# Altium schematic sheet Schematic.SchDoc
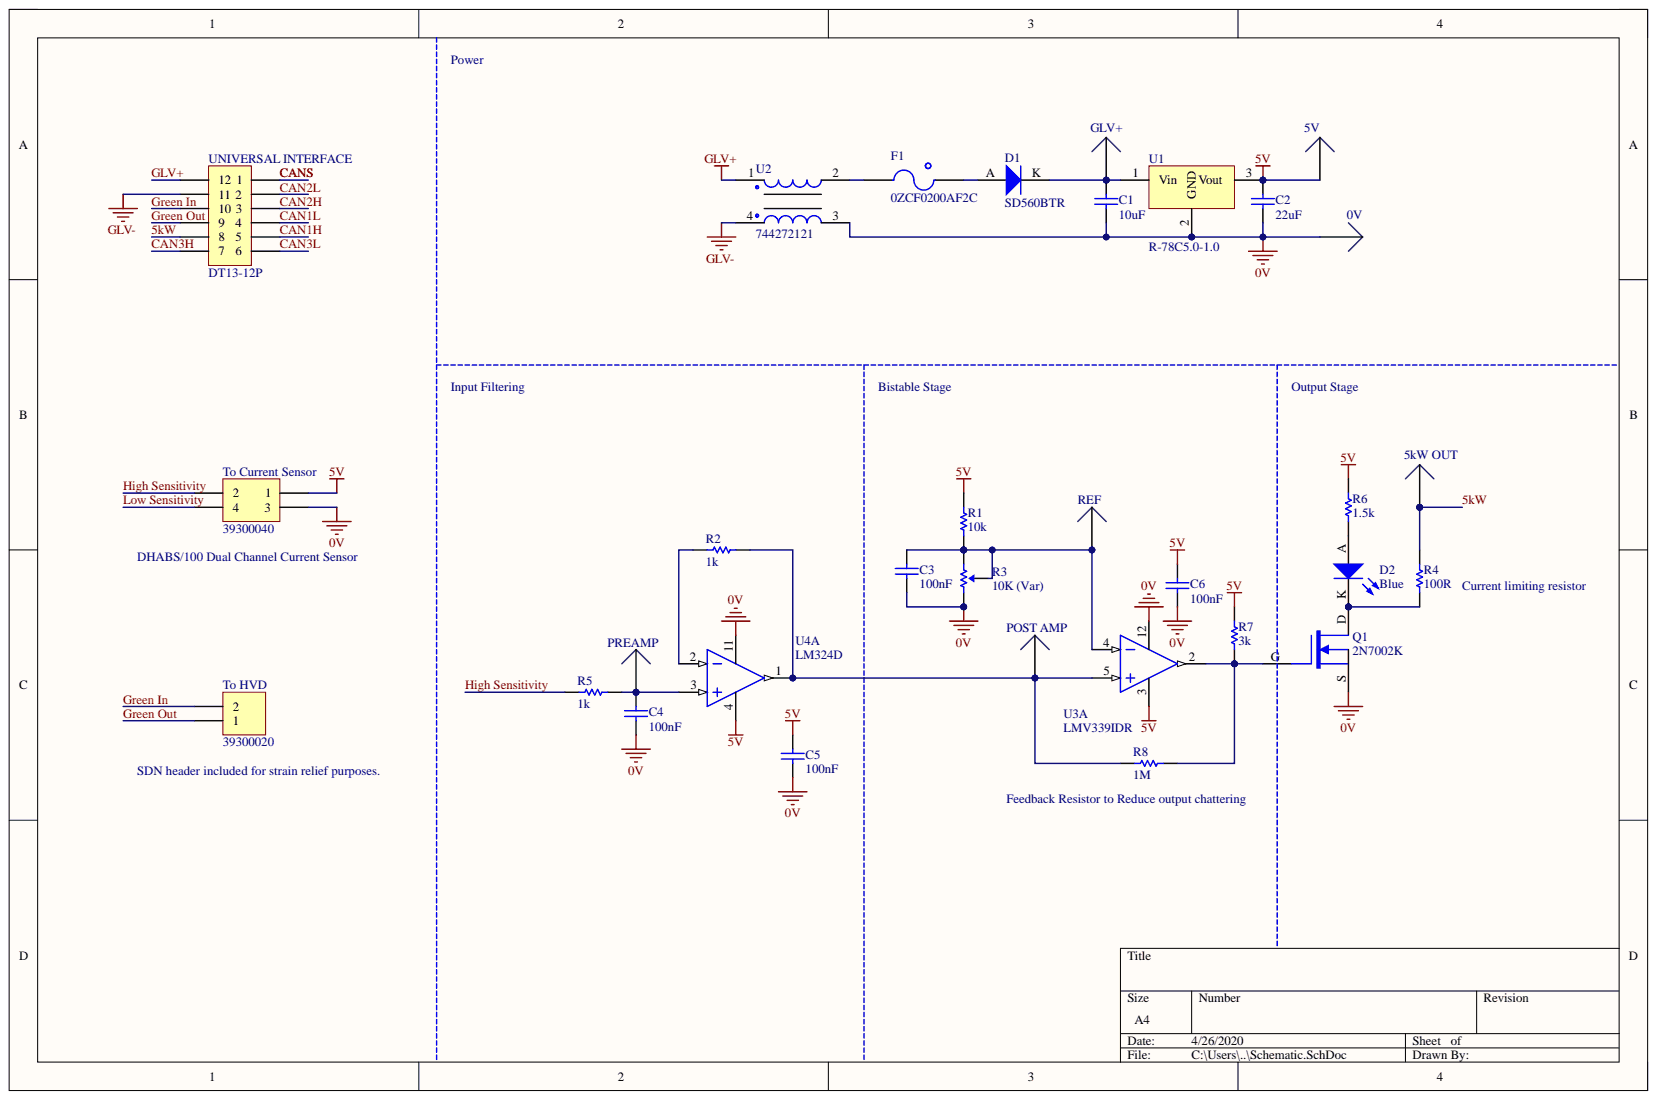
[diagram p0: Schematic.SchDoc]

## Parts
0V  0mm  [TH Test Point]  (value not drawn)
5kW_OUT  0mm  [TH Test Point]  (value not drawn)
5V  0mm  [TH Test Point]  (value not drawn)
C1  10uF  [0805]
C2  22uF  [1206]
C3  100nF  [0805]
C4  100nF  [0805]
C5  100nF  [0805]
C6  100nF  [0805]
D1  SD560BTR  [SMB Diode]
D2  Blue  [0805 LED]
F1  0ZCF0200AF2C  [2920]
GLV+  0mm  [TH Test Point]  (value not drawn)
POST_AMP  0mm  [TH Test Point]  (value not drawn)
PREAMP  0mm  [TH Test Point]  (value not drawn)
Q1  2N7002K  [ON SOT-23 MOSFET]
R1  10k  [0805]
R2  1k  [0805]
R3  10K (Var)  [B 3296]
R4  100R  [0805]
R5  1k  [0805]
R6  1.5k  [0805]
R7  3k  [0805]
R8  1M  [0805]
REF  0mm  [TH Test Point]  (value not drawn)
To_Current_Sensor  39300040  [39300040]
To_HVD  39300020  [39300020]
U1  R-78C5.0-1.0  [RECOM 3-SIP]
U2  744272121  [Wurth 4-PC Pad]
U3  LMV339IDR  [TI 14-SOIC]  (part A)
U4  LM324D  [TI 14-SOIC]  (part A)
UNIVERSAL_INTERFACE  DT13-12P  [DT13-12P]

## Nets
0V: 0V.1 C1.1 C2.1 C3.1 C4.1 C5.1 C6.1 Q1.S R3.3 To_Current_Sensor.3 U1.2 U2.3 U3.12 U4.11
5V: 5V.1 C2.2 C5.2 C6.2 R1.2 R6.2 R7.2 To_Current_Sensor.1 U1.3 U3.3 U4.4
GLV-: U2.4 UNIVERSAL_INTERFACE.11
5kW: 5kW_OUT.1 R4.2 UNIVERSAL_INTERFACE.8
CAN1H: UNIVERSAL_INTERFACE.5
CAN1L: UNIVERSAL_INTERFACE.4
CAN2H: UNIVERSAL_INTERFACE.3
CAN2L: UNIVERSAL_INTERFACE.2
CAN3H: UNIVERSAL_INTERFACE.7
CAN3L: UNIVERSAL_INTERFACE.6
CANS: UNIVERSAL_INTERFACE.1
GLV+: U2.1 UNIVERSAL_INTERFACE.12
Green In: To_HVD.2 UNIVERSAL_INTERFACE.10
Green Out: To_HVD.1 UNIVERSAL_INTERFACE.9
High Sensitivity: R5.1 To_Current_Sensor.2
Low Sensitivity: To_Current_Sensor.4
NetC1_2: C1.2 D1.K GLV+.1 U1.1
NetC3_2: C3.2 R1.1 R3.1 R3.2 REF.1 U3.4
NetC4_2: C4.2 PREAMP.1 R5.2 U4.3
NetD1_A: D1.A F1.2
NetD2_A: D2.A R6.1
NetD2_K: D2.K Q1.D R4.1
NetF1_1: F1.1 U2.2
NetPOST AMP_1: POST_AMP.1 R2.2 R8.1 U3.5 U4.1
NetQ1_G: Q1.G R7.1 R8.2 U3.2
NetR2_1: R2.1 U4.2

## Library data of the parts
0V 5kW_OUT 5V GLV+ POST_AMP PREAMP REF = TH Test Point: Angle: 0; Contact: NA; Database Table Name: Generic$; Flange: No; Positions: 1; Component Kind: Standard; Library Name: ts_20-standard-connectors.DbLib; Part Number: TH Test Point; Pitch: 0mm; Row Spacing: 0mm
C1 = GRM21BR6YA106KE43L: Manufacturer: muRata; Database Table Name: Capacitors$; Material: X5R; Rated Voltage: 50V; Tolerance: 10%; Component Kind: Standard; Digikey Part Number: 490-10514-6-ND; Library Name: ts_20-standard-library.DbLib; Part Number: GRM21BR6YA106KE43L; Value: 10uF
C2 = CC1206MKX5R7BB226: Manufacturer: YAGEO; Database Table Name: Capacitors$; Material: Ceramic; Rated Voltage: 16V; Tolerance: 20%; Component Kind: Standard; Digikey Part Number: 311-1997-2-ND; Library Name: ts_20-standard-library.DbLib; Part Number: CC1206MKX5R7BB226; Value: 22uF
C3-C6 = GCM21BR71E104KA37L: Manufacturer: muRata; Database Table Name: Capacitors$; Material: X7R; Rated Voltage: 25V; Tolerance: 10%; Component Kind: Standard; Library Name: ts_20-standard-library.DbLib; Part Number: GCM21BR71E104KA37L; Value: 100nF
D1 = SD560BTR: 1.2V_F 600V 5A SMB; Manufacturer: SMC Diode Solutions; Database Table Name: Diodes$; Component Kind: Standard; Digikey Link: https://www.digikey.com/product-detail/en/smc-diode-solutions/SD560BTR/1655-1530-2-ND/6022620; Digikey Part Number: 1655-1530-2-ND; Library Name: ts_20-standard-library.DbLib; Part Number: SD560BTR
D2 = SML-MN2BCTT86C: LED BLUE CLEAR 2012 SMD; Manufacturer: ROHM Semiconductor; Database Table Name: LEDs$; Colour: Blue; Component Kind: Standard; Digikey Link: https://www.digikey.com/product-detail/en/rohm-semiconductor/SMLMN2BCTT86C/846-1207-1-ND/6057239; Digikey Part Number: 846-1207-1-ND; Library Name: ts_20-standard-library.DbLib; Part Number: SML-MN2BCTT86C
F1 = 0ZCF0200AF2C: SMD 24V 2A Fuse; Manufacturer: Bel Fuse Inc; Database Table Name: Fuses$; Component Kind: Standard; Digikey Link: https://www.digikey.com/product-detail/en/bel-fuse-inc/0ZCF0200AF2C/507-1749-2-ND/4156090; Digikey Part Number: 507-1749-2-ND; Library Name: ts_20-standard-library.DbLib; Part Number: 0ZCF0200AF2C
Q1 = 2N7002K: 60V 380mA, NFET MOSTFET (Small Signal); Manufacturer: ON Semiconductor; Database Table Name: Transistors$; Component Kind: Standard; Digikey Link: https://www.digikey.com/product-detail/en/on-semiconductor/2N7002K/2N7002KCT-ND/2060375; Digikey Part Number: 2N7002KCT-ND; LatestRevisionDate: 29-May-2009; LatestRevisionNote: IPC-7351 Footprint Added.; Library Name: ts_20-standard-library.DbLib; PackageDescription: 4-Pin SOT-343 Package 1.3 mm Pitch, 2.1 mm Lead Span; PackageReference: SOT-343; PackageVersion: Sep-2000; Part Number: 2N7002K; Published: 24-Mar-1999; Qty: 30; Simulation: NMOS; Publisher: Altium Limited
R1 = RC0805FR0710KL: Manufacturer: YAGEO; Database Table Name: Resistors$; Rated Voltage: 0.125W; Tolerance: 0.01; Component Kind: Standard; Library Name: ts_20-standard-library.DbLib; Material: FR-07; Part Number: RC0805FR0710KL; Value: 10k
R2 R5 = RC0805FR071KL: Manufacturer: YAGEO; Database Table Name: Resistors$; Rated Voltage: 0.125W; Tolerance: 0.01; Component Kind: Standard; Library Name: ts_20-standard-library.DbLib; Material: FR-07; Part Number: RC0805FR071KL; Value: 1k
R3 = 3296W-1-103LF: Manufacturer: Bournes Inc; Database Table Name: Resistors$; Rated Voltage: 0.5W; Tolerance: 0.1; Component Kind: Standard; Digikey Part Number: 3296W-103LF-ND; Library Name: ts_20-standard-library.DbLib; Part Number: 3296W-1-103LF; Value: 10K (Var)
R4 = RC0805FR07100RL: Manufacturer: YAGEO; Database Table Name: Resistors$; Rated Voltage: 0.125W; Tolerance: 0.01; Component Kind: Standard; Library Name: ts_20-standard-library.DbLib; Material: FR-07; Part Number: RC0805FR07100RL; Value: 100R
R6 = RC0805FR071K5L: Manufacturer: YAGEO; Database Table Name: Resistors$; Rated Voltage: 0.125W; Tolerance: 0.01; Component Kind: Standard; Library Name: ts_20-standard-library.DbLib; Material: FR-07; Part Number: RC0805FR071K5L; Value: 1.5k
R7 = RC0805FR073KL: Manufacturer: YAGEO; Database Table Name: Resistors$; Rated Voltage: 0.125W; Tolerance: 0.01; Component Kind: Standard; Library Name: ts_20-standard-library.DbLib; Material: FR-07; Part Number: RC0805FR073KL; Value: 3k
R8 = RC0805FR071ML: Manufacturer: YAGEO; Database Table Name: Resistors$; Rated Voltage: 0.125W; Tolerance: 0.01; Component Kind: Standard; Digikey Part Number: 311-1.00MCRCT-ND; Library Name: ts_20-standard-library.DbLib; Material: FR-07; Part Number: RC0805FR071ML; Value: 1M
To_Current_Sensor = 39300040: Angle: 90; Contact: Tin; Database Table Name: Molex Minifit$; Flange: No; Pitch: 4.2mm; Positions: 4; Row Spacing: 5.5mm; Component Kind: Standard; Digikey Part Number: WM21352-ND; Library Name: ts_20-standard-connectors.DbLib; Part Number: 39300040
To_HVD = 39300020: Angle: 90; Contact: Tin; Database Table Name: Molex Minifit$; Flange: No; Pitch: 4.2mm; Positions: 2; Row Spacing: 5.5mm; Component Kind: Standard; Digikey Part Number: WM21351-ND; Library Name: ts_20-standard-connectors.DbLib; Part Number: 39300020
U1 = R-78C5.0-1.0: CONV DC/DC 1A 5V OUT SIP VERT; Manufacturer: Recom; Database Table Name: Regulators$; Isolation: Non-Isolated; Component Kind: Standard; Digikey Link: https://www.digikey.com.au/product-detail/en/recom-power/R-78C5.0-1.0/945-1395-5-ND/2652148; Digikey Part Number: 945-1395-5-ND; Library Name: ts_20-standard-library.DbLib; Part Number: R-78C5.0-1.0
U2 = 744272121: Common Mode Choke 120UH 2.5A 2LN 460 OHM SMD; Manufacturer: Wurth Electronics Inc; Database Table Name: Integrated Components$; Component Kind: Standard; Digikey Link: https://www.digikey.com/product-detail/en/wurth-electronics-inc/744272121/732-1489-2-ND/1638893; Digikey Part Number: 732-1489-2-ND; Library Name: ts_20-standard-library.DbLib; Part Number: 744272121
U3 = LMV339IDR: Comparator General Purpose Open Collector 14-SOIC; Manufacturer: Texas Instruments; Database Table Name: Integrated Components$; Component Kind: Standard; Digikey Link: https://www.digikey.com/product-detail/en/texas-instruments/LMV339MTX-NOPB/296-35446-1-ND/3739150; Digikey Part Number: 296-6611-2-ND; Library Name: ts_20-standard-library.DbLib; Part Number: LMV339IDR
U4 = LM324D: IC OPAMP GP 4 CIRCUIT 14SOIC; Manufacturer: Texas Instruments; Database Table Name: Integrated Components$; Component Kind: Standard; Digikey Link: https://www.digikey.com/product-detail/en/texas-instruments/LM324D/296-1390-5-ND/277039; Digikey Part Number: 296-1390-5-ND; Library Name: ts_20-standard-library.DbLib; Part Number: LM324D
UNIVERSAL_INTERFACE = DT13-12P[[/\]]DT13-12P: Angle: 90; Contact: Nickel; Database Table Name: Deutsch DT$; Flange: Yes; Pitch: 4.44mm; Positions: 12; Row Spacing: 6.35mm; Component Kind: Standard; Digikey Link: https://www.digikey.com.au/product-detail/en/te-connectivity-deutsch-connectors/DT13-12PA/1734-1451-ND/7220123; Digikey Part Number: 1734-1451-ND; Library Name: ts_20-standard-connectors.DbLib; Part Number: DT13-12P
